# Altium schematic sheet PWM_Generator.SchDoc
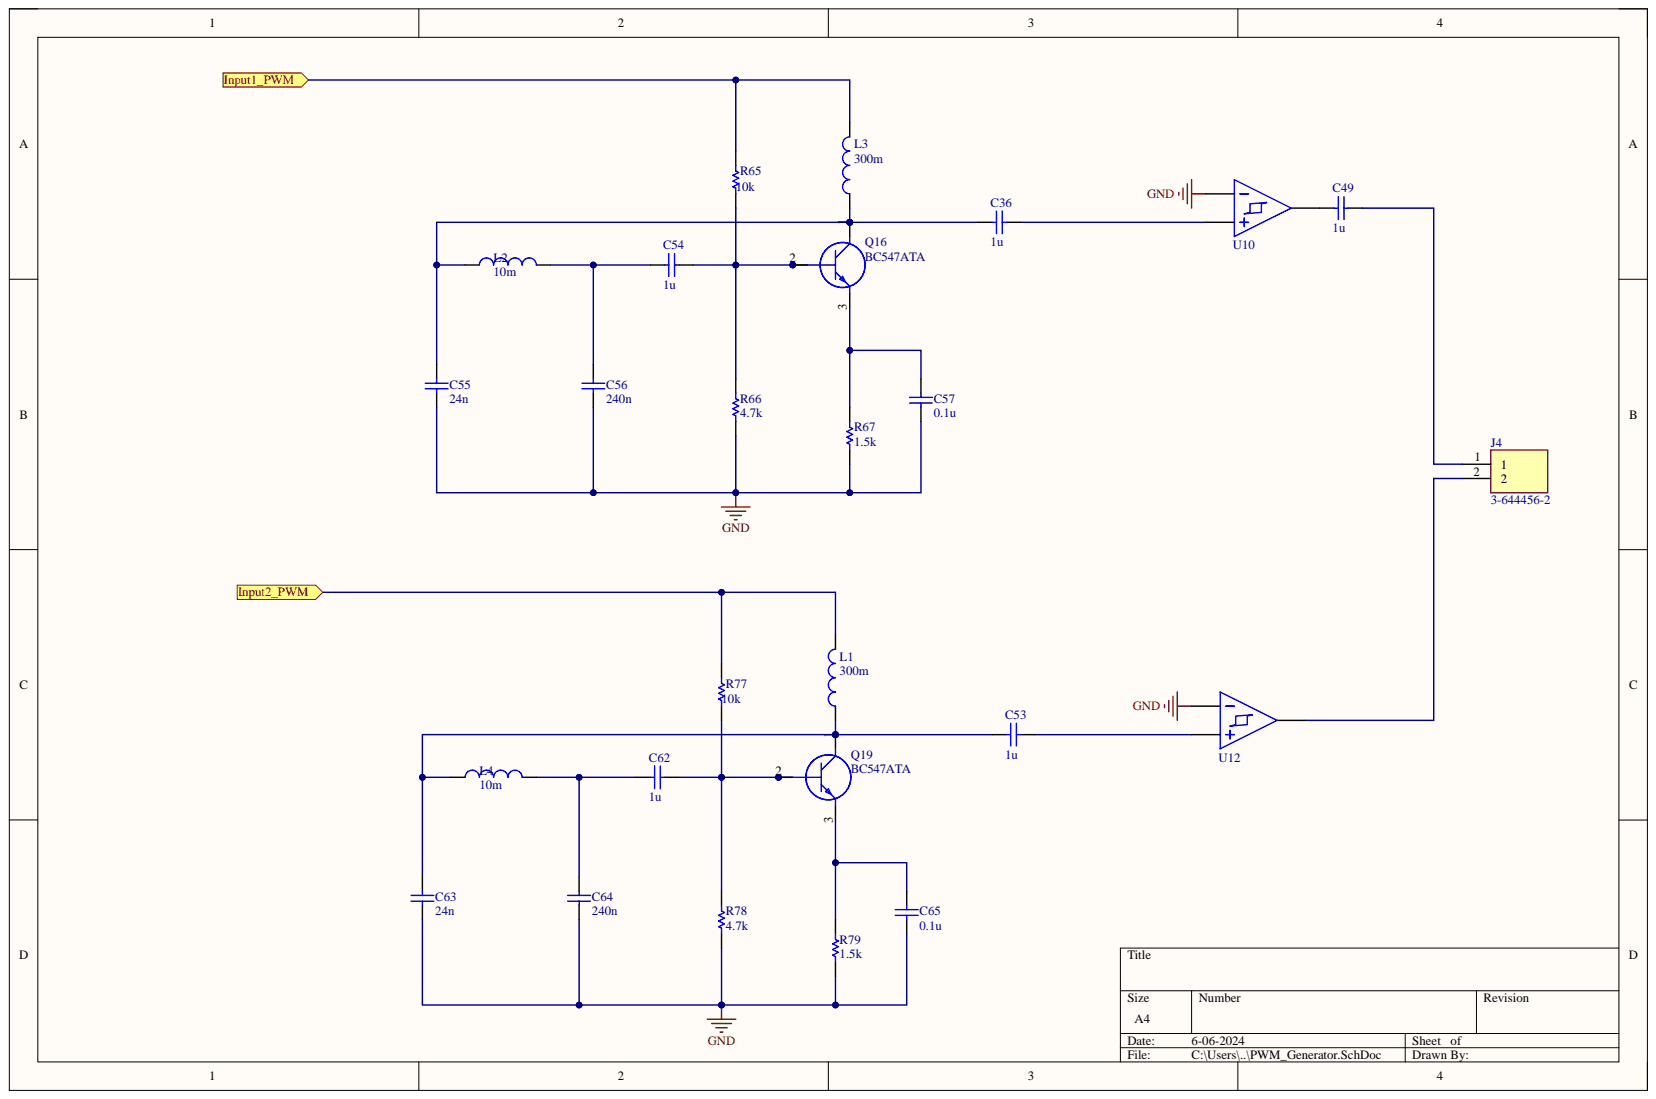
[diagram p0: PWM_Generator.SchDoc]

## Parts
C36  value 1u  (hidden comment: Capacitor)
C49  value 1u  (hidden comment: Capacitor)
C53  value 1u  (hidden comment: Capacitor)
C54  value 1u  (hidden comment: Capacitor)
C55  value 24n  (hidden comment: Capacitor)
C56  value 240n  (hidden comment: Capacitor)
C57  value 0.1u  (hidden comment: Capacitor)
C62  value 1u  (hidden comment: Capacitor)
C63  value 24n  (hidden comment: Capacitor)
C64  value 240n  (hidden comment: Capacitor)
C65  value 0.1u  (hidden comment: Capacitor)
J4  3-644456-2  [HDRV2W67P0X254_1X2_478X234X1029P]
L1  value 300m  (hidden comment: Inductor)
L2  value 10m  (hidden comment: Inductor)
L3  value 300m  (hidden comment: Inductor)
L4  value 10m  (hidden comment: Inductor)
Q16  BC547ATA  [TO-92_(TO-226)]
Q19  BC547ATA  [TO-92_(TO-226)]
R65  value 10k  (hidden comment: Resistor)
R66  value 4.7k  (hidden comment: Resistor)
R67  value 1.5k  (hidden comment: Resistor)
R77  value 10k  (hidden comment: Resistor)
R78  value 4.7k  (hidden comment: Resistor)
R79  value 1.5k  (hidden comment: Resistor)
U10  Ideal Comparator  (value not drawn)
U12  Ideal Comparator  (value not drawn)

## Ports
Input1_PWM, Input2_PWM

## Nets
GND: C55.1 C56.1 C57.1 C63.1 C64.1 C65.1 R66.1 R67.1 R78.1 R79.1 U10.1 U12.1
Input1_PWM: L3.2 R65.2 ; port Input1_PWM
Input2_PWM: L1.2 R77.2 ; port Input2_PWM
NetC36_1: C36.1 C55.2 L2.1 L3.1 Q16.1
NetC36_2: C36.2 U10.2
NetC49_1: C49.1 U10.3
NetC49_2: C49.2 J4.1
NetC53_1: C53.1 C63.2 L1.1 L4.1 Q19.1
NetC53_2: C53.2 U12.2
NetC54_1: C54.1 C56.2 L2.2
NetC54_2: C54.2 Q16.2 R65.1 R66.2
NetC57_2: C57.2 Q16.3 R67.2
NetC62_1: C62.1 C64.2 L4.2
NetC62_2: C62.2 Q19.2 R77.1 R78.2
NetC65_2: C65.2 Q19.3 R79.2
NetJ4_2: J4.2 U12.3

## Library data of the parts
C36 C49 C53-C57 C62-C65 = Capacitor: SimulationGenericComponent: True; Component Kind: Standard; Description: Capacitor; Library Name: Simulation Generic Components.IntLib; Simulation: C_Capacitor_Default
J4 = 3-644456-2: Connector; Manufacturer_Name: TE Connectivity; Arrow Part Number: 3-644456-2; Arrow Price/Stock: https://www.arrow.com/en/products/3-644456-2/te-connectivity?region=nac; Component Kind: Standard; Datasheet Link: https://www.te.com/commerce/DocumentDelivery/DDEController?Action=showdoc&DocId=Customer+Drawing%7F644456%7FD2%7Fpdf%7FEnglish%7FENG_CD_644456_D2.pdf%7F3-644456-2; Height: 10.287mm; Manufacturer_Part_Number: 3-644456-2; Mouser Part Number: 571-3-644456-2; Mouser Price/Stock: https://www.mouser.co.uk/ProductDetail/TE-Connectivity/3-644456-2?qs=AplfTeSvkkCnnz4NxZTwTQ%3D%3D
L1-L4 = Inductor: SimulationGenericComponent: True; Component Kind: Standard; Description: Inductor; Library Name: Simulation Generic Components.IntLib; Simulation: L_Inductor_Default
Q16 Q19 = BC547ATA: Transistor BJT NPN; Manufacturer_Name: onsemi; Arrow Part Number: BC547ATA; Arrow Price/Stock: https://www.arrow.com/en/products/bc547ata/on-semiconductor?region=europe; Component Kind: Standard; Datasheet Link: https://www.onsemi.com/pub/Collateral/BC550-D.pdf; Manufacturer_Part_Number: BC547ATA; Mouser Part Number: 512-BC547ATA; Mouser Price/Stock: https://www.mouser.co.uk/ProductDetail/onsemi-Fairchild/BC547ATA?qs=ljbEvF4DwOMRdLfuzO8N4w%3D%3D; Simulation: NPN_Mod_Gummel_Poon
R65-R67 R77-R79 = Resistor: SimulationGenericComponent: True; TC1: 0; Component Kind: Standard; Description: Resistor; Library Name: Simulation Generic Components.IntLib; Simulation: R_Resistor_Default; TC2: 0
U10 = Comparator: SimulationGenericComponent: True; VHIGH: 3.3; VHYS: 50m; VLOW: 0; Component Kind: Standard; Description: Ideal Comparator; Library Name: Simulation Generic Components.IntLib; Simulation: IDEAL_COMPARATOR_BASSO_HYS
U12 = Comparator: SimulationGenericComponent: True; VHIGH: 5; VHYS: 50m; VLOW: 100m; Component Kind: Standard; Description: Ideal Comparator; Library Name: Simulation Generic Components.IntLib; Simulation: IDEAL_COMPARATOR_BASSO_HYS
